# Altium schematic sheet AUDIO&KEY.SchDoc(AUDIO&KEY)
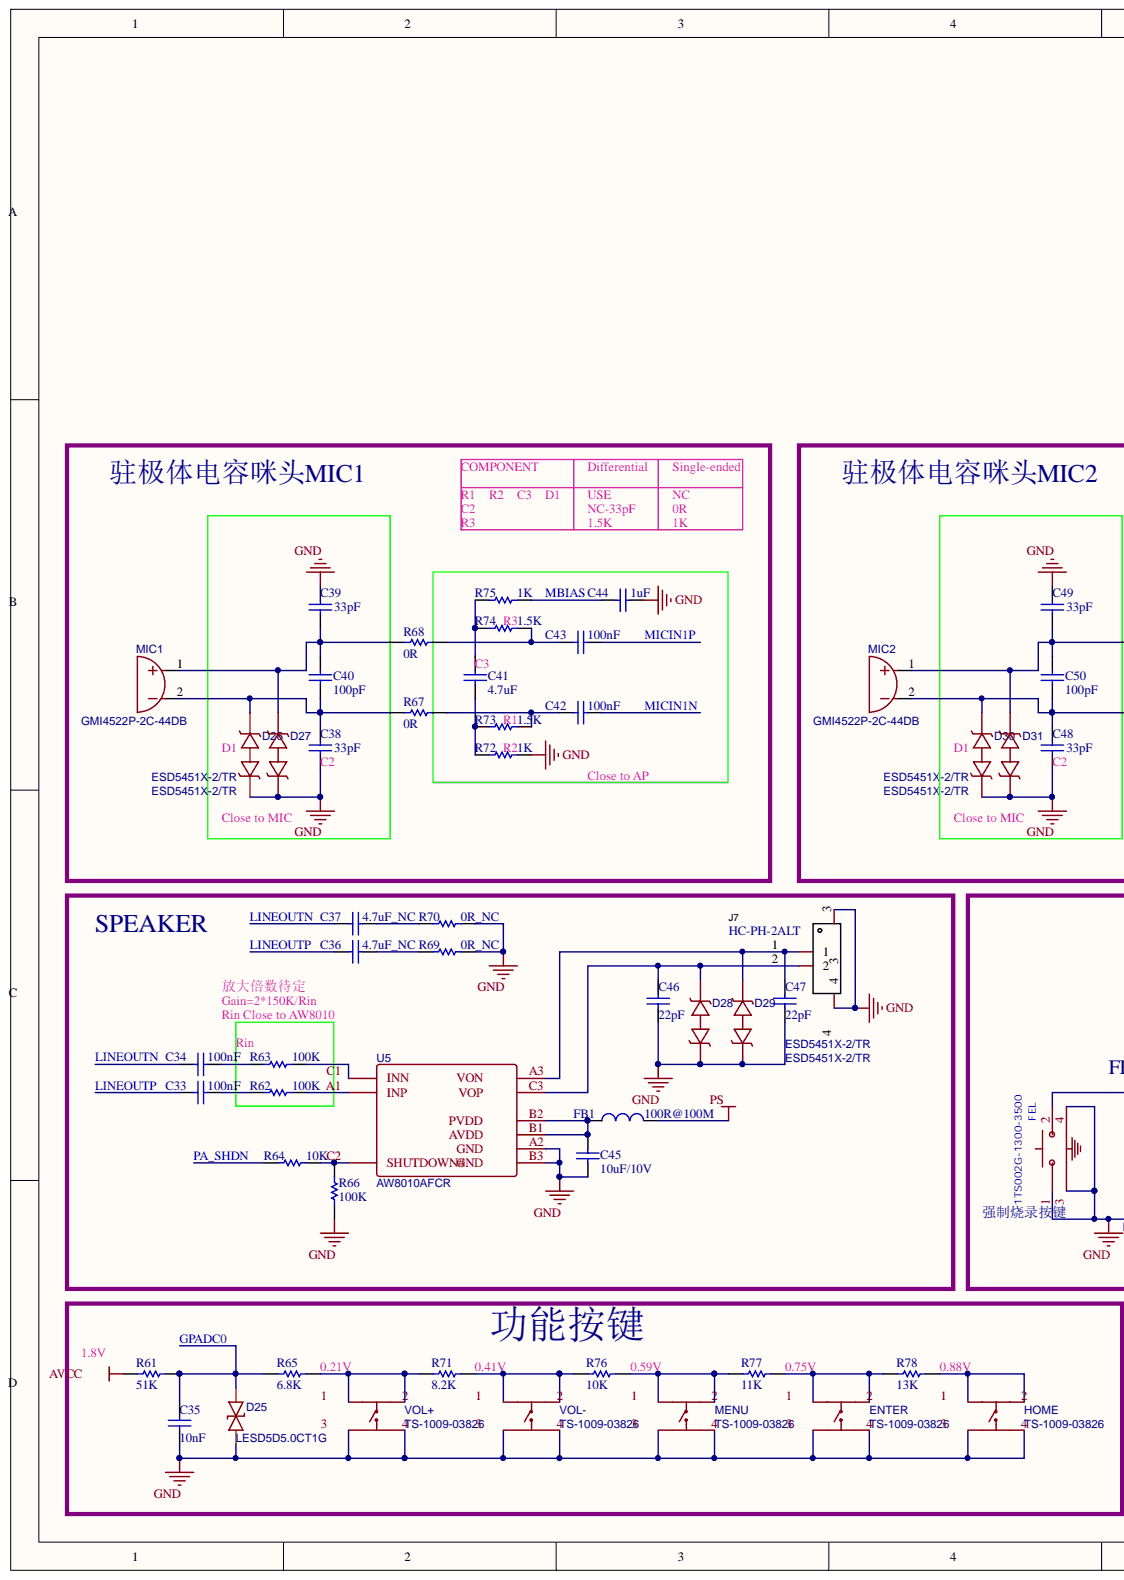
[diagram p0: AUDIO&KEY.SchDoc, left]
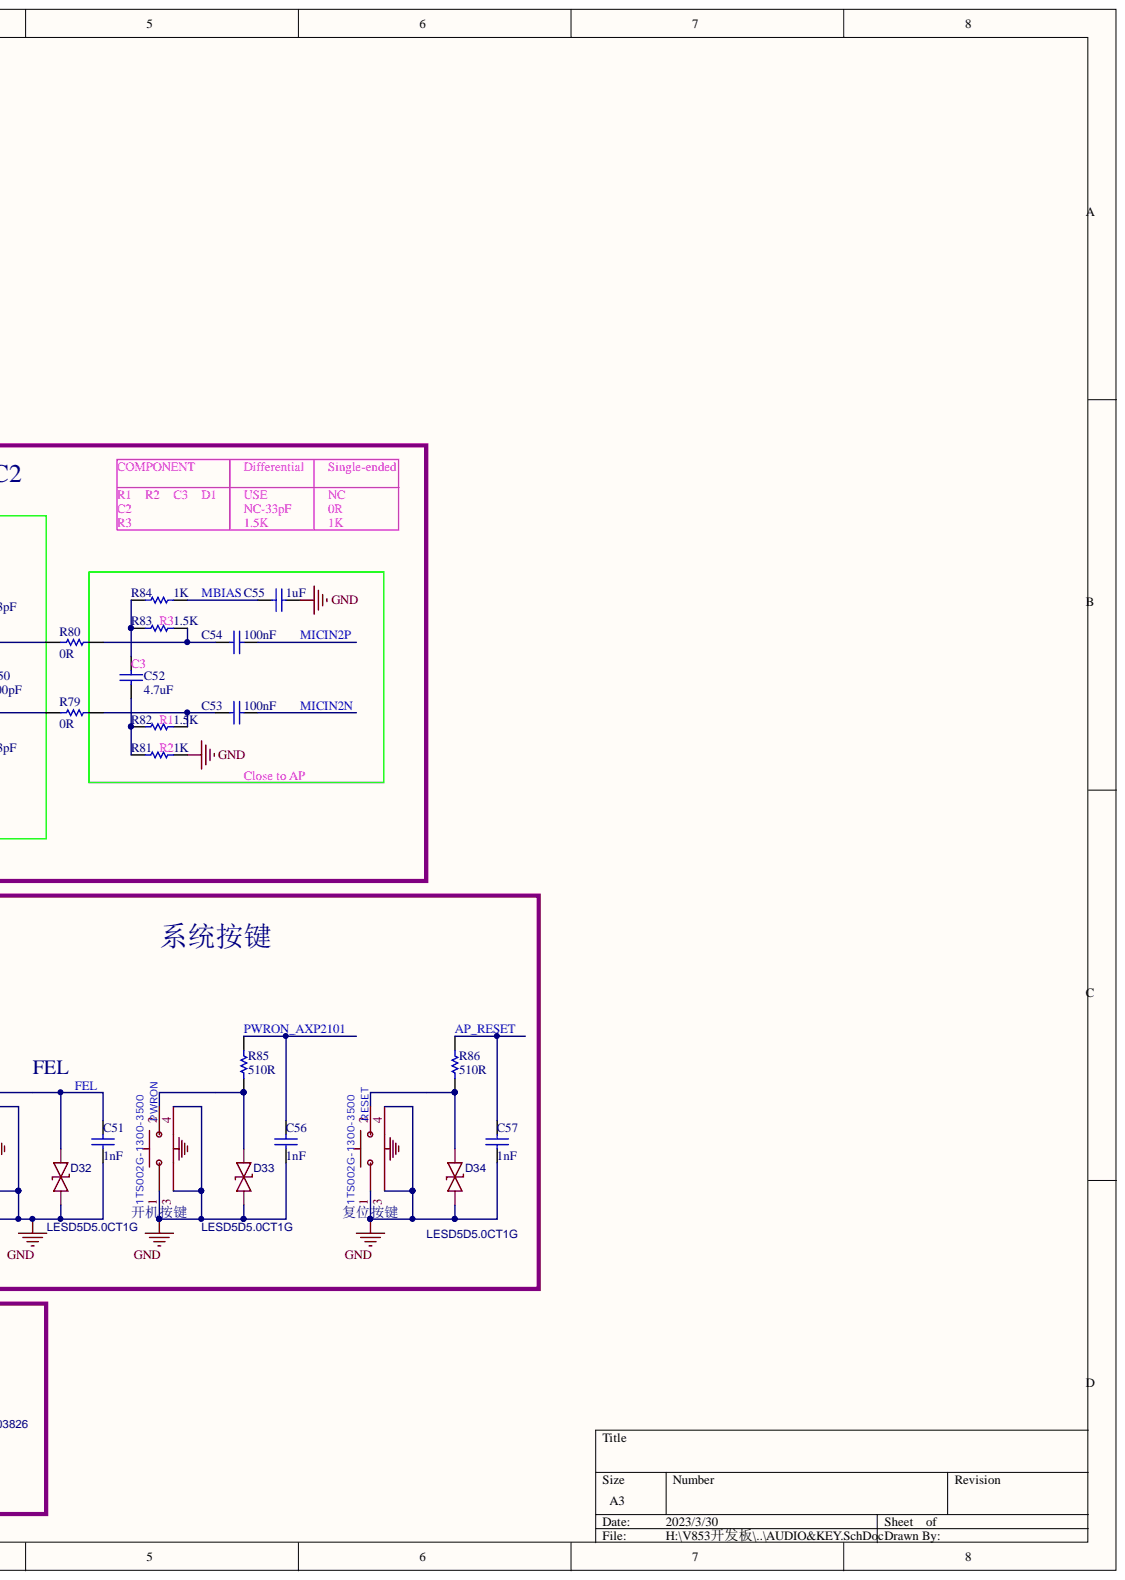
[diagram p1: AUDIO&KEY.SchDoc, right]

## Parts
C33  100nF  [0402]
C34  100nF  [0402]
C35  10nF  [0402]
C36  4.7uF_NC  [0402]
C37  4.7uF_NC  [0402]
C38  33pF  [0402]
C39  33pF  [0402]
C40  100pF  [0402]
C41  4.7uF  [0402]
C42  100nF  [0402]
C43  100nF  [0402]
C44  1uF  [0402]
C45  10uF/10V  [0402]
C46  22pF  [0402]
C47  22pF  [0402]
C48  33pF  [0402]
C49  33pF  [0402]
C50  100pF  [0402]
C51  1nF  [0402]
C52  4.7uF  [0402]
C53  100nF  [0402]
C54  100nF  [0402]
C55  1uF  [0402]
C56  1nF  [0402]
C57  1nF  [0402]
D25  LESD5D5.0CT1G  [SOD-523_L1.2-W0.8-LS1.6-BI]
D26  ESD5451X-2/TR  [FBP-02C_L1.0-W0.6-RD]
D27  ESD5451X-2/TR  [FBP-02C_L1.0-W0.6-RD]
D28  ESD5451X-2/TR  [FBP-02C_L1.0-W0.6-RD]
D29  ESD5451X-2/TR  [FBP-02C_L1.0-W0.6-RD]
D30  ESD5451X-2/TR  [FBP-02C_L1.0-W0.6-RD]
D31  ESD5451X-2/TR  [FBP-02C_L1.0-W0.6-RD]
D32  LESD5D5.0CT1G  [SOD-523_L1.2-W0.8-LS1.6-BI]
D33  LESD5D5.0CT1G  [SOD-523_L1.2-W0.8-LS1.6-BI]
D34  LESD5D5.0CT1G  [SOD-523_L1.2-W0.8-LS1.6-BI]
ENTER  TS-1009-03826  [SW-TH_4P-L4.5-W4.5-P3.00-LS5.5]
FB1  100R@100M  [0603]
FEL  1TS002G-1300-3500  [SW-SMD_1TS002G-XXXX-3500-CT]
HOME  TS-1009-03826  [SW-TH_4P-L4.5-W4.5-P3.00-LS5.5]
J7  HC-PH-2ALT  [CONN-SMD_HC-PH-2ALT]
MENU  TS-1009-03826  [SW-TH_4P-L4.5-W4.5-P3.00-LS5.5]
MIC1  GMI4522P-2C-44DB  [MIC-TH_BD4.5-P1.60-D0.40-L-FD]
MIC2  GMI4522P-2C-44DB  [MIC-TH_BD4.5-P1.60-D0.40-L-FD]
PWRON  1TS002G-1300-3500  [SW-SMD_1TS002G-XXXX-3500-CT]
R61  51K  [0402]
R62  100K  [0402]
R63  100K  [0402]
R64  10K  [0402]
R65  6.8K  [0402]
R66  100K  [0402]
R67  0R  [0402]
R68  0R  [0402]
R69  0R_NC  [0402]
R70  0R_NC  [0402]
R71  8.2K  [0402]
R72  1K  [0402]
R73  1.5K  [0402]
R74  1.5K  [0402]
R75  1K  [0402]
R76  10K  [0402]
R77  11K  [0402]
R78  13K  [0402]
R79  0R  [0402]
R80  0R  [0402]
R81  1K  [0402]
R82  1.5K  [0402]
R83  1.5K  [0402]
R84  1K  [0402]
R85  510R  [0402]
R86  510R  [0402]
RESET  1TS002G-1300-3500  [SW-SMD_1TS002G-XXXX-3500-CT]
U5  AW8010AFCR  [FC-9_L1.5-W1.5-R3-C3-P0.50-BL]
VOL+  TS-1009-03826  [SW-TH_4P-L4.5-W4.5-P3.00-LS5.5]
VOL-  TS-1009-03826  [SW-TH_4P-L4.5-W4.5-P3.00-LS5.5]

## Nets
AVCC: R61.2
GND: C35.2 C38.2 C39.1 C44.1 C45.2 C46.2 C47.2 C48.2 C49.1 C51.2 C55.1 C56.2 C57.2 D25.1 D26.1 D27.1 D28.1 D29.1 D30.1 D31.1 D32.1 D33.1 D34.1 ENTER.3 ENTER.4 FEL.1 FEL.3 FEL.4 HOME.3 HOME.4 J7.3 J7.4 MENU.3 MENU.4 PWRON.1 PWRON.3 PWRON.4 R66.1 R69.2 R70.2 R72.2 R81.2 RESET.1 RESET.3 RESET.4 U5.A2 U5.B3 VOL+.3 VOL+.4 VOL-.3 VOL-.4
PS: FB1.2
AP_RESET: C57.1 R86.1
FEL: C51.1 D32.2 FEL.2
GPADC0: C35.1 D25.2 R61.1 R65.2
LINEOUTN: C34.2 C37.2
LINEOUTP: C33.2 C36.2
MBIAS: C44.2 C55.2 R75.2 R84.2
MICIN1N: C42.1
MICIN1P: C43.1
MICIN2N: C53.1
MICIN2P: C54.1
PA_SHDN: R64.1
PWRON_AXP2101: C56.1 R85.1
NetC33_1: C33.1 R62.1
NetC34_1: C34.1 R63.1
NetC36_1: C36.1 R69.1
NetC37_1: C37.1 R70.1
NetC38_1: C38.1 C40.2 D26.2 MIC1.2 R67.1
NetC39_2: C39.2 C40.1 D27.2 MIC1.1 R68.1
NetC41_1: C41.1 R74.1 R75.1
NetC41_2: C41.2 R72.1 R73.1
NetC42_2: C42.2 R67.2 R73.2
NetC43_2: C43.2 R68.2 R74.2
NetC45_1: C45.1 FB1.1 U5.B1 U5.B2
NetC46_1: C46.1 D28.2 J7.2 U5.C3
NetC47_1: C47.1 D29.2 J7.1 U5.A3
NetC48_1: C48.1 C50.2 D30.2 MIC2.2 R79.1
NetC49_2: C49.2 C50.1 D31.2 MIC2.1 R80.1
NetC52_1: C52.1 R83.1 R84.1
NetC52_2: C52.2 R81.1 R82.1
NetC53_2: C53.2 R79.2 R82.2
NetC54_2: C54.2 R80.2 R83.2
NetD33_2: D33.2 PWRON.2 R85.2
NetD34_2: D34.2 R86.2 RESET.2
NetENTER_1: ENTER.1 ENTER.2 R77.1 R78.2
NetHOME_1: HOME.1 HOME.2 R78.1
NetMENU_1: MENU.1 MENU.2 R76.1 R77.2
NetR62_2: R62.2 U5.A1(INP)
NetR63_2: R63.2 U5.C1(INN)
NetR64_2: R64.2 R66.2 U5.C2(SHUTDOWN#)
NetR65_1: R65.1 R71.2 VOL+.1 VOL+.2
NetR71_1: R71.1 R76.2 VOL-.1 VOL-.2

## Library data of the parts
C33-C57 = Cap: Capacitor; PartNumber: CAP100NF; Component Kind: Standard; LatestRevisionDate: 17-Jul-2002; LatestRevisionNote: Re-released for DXP Platform.; Library Name: Miscellaneous Devices.IntLib; PackageReference: 0402; Published: 8-Jun-2000; Signal Integrity: Cap; Simulation: CAP; Publisher: Altium Limited
  C33 C34 C42 C43 C53 C54: Value: 100nF
  C35: Value: 10nF
  C36 C37: Value: 4.7uF_NC
  C38 C39 C48 C49: Value: 33pF
  C40 C50: Value: 100pF
  C41 C52: Value: 4.7uF
  C44 C55: Value: 1uF
  C45: Value: 10uF/10V
  C46 C47: Value: 22pF
  C51 C56 C57: Value: 1nF
D25 D32-D34 = LESD5D5.0CT1G: BOM_Manufacturer: Leshan Radio; Contributor: LCSC; BOM_JLCPCB Part Class: Extended Part; BOM_Manufacturer Part: LESD5D5.0CT1G; BOM_Supplier: LCSC; BOM_Supplier Part: C383211; Component Kind: Standard; spicePre: D; spiceSymbolName: LESD5D5.0CT1G
D26-D31 = ESD5451X-2/TR: BOM_Manufacturer: Will Semicon; Contributor: LCSC; BOM_JLCPCB Part Class: Extended Part; BOM_Manufacturer Part: ESD5451X-2/TR; BOM_Supplier: LCSC; BOM_Supplier Part: C239558; Component Kind: Standard; spicePre: D; spiceSymbolName: ESD5451X-2/TR
ENTER HOME MENU VOL+ VOL- = KEY_C174049: BOM_Manufacturer: BOOMELE(Boom Precision Elec); Contributor: LCSC; SMT Type: Extend; BOM_JLCPCB SMD: Yes; BOM_Supplier: LCSC; BOM_Supplier Part: C455237; Component Kind: Standard; Library Name: HM2.SchLib; spicePre: S; spiceSymbolName: C455237
FB1 = Inductor: Component Kind: Standard; Library Name: MyDevice.SchLib; Value: 100R@100M
J7 = HC-PH-2ALT: Add into BOM: yes; Current Rating (Max): 2A; Number of PINs Per Row: 2; Pins Structure: 1x2P; Pitch: 2mm; Component Kind: Standard; Convert to PCB: yes; Datasheet: https://atta.szlcsc.com/upload/public/pdf/source/20210728/C2845451_BA459BCD93EBE6AA1DA880EFD46E3DBA.pdf; Device: HC-PH-2ALT; JLCPCB Part Class: Extended Part; Manufacturer Part: HC-PH-2ALT; Name: HC-PH-2ALT; Number of Rows: 1; PCB3D: CONN-SMD_HC-PH-2ALT; Reference Series: -; Row Spacing: -; Supplier: LCSC; Supplier Footprint: SMD,P=2mm; Supplier Part: C2845451; Symbol: HC-PH-2ALT
MIC1 MIC2 = GMI4522P-2C-44DB: BOM_Manufacturer: INGHAi; Contributor: LCSC; BOM_JLCPCB Part Class: Extended Part; BOM_Manufacturer Part: GMI4522P-2C-44db; BOM_Supplier: LCSC; BOM_Supplier Part: C233312; Component Kind: Standard; spicePre: M; spiceSymbolName: GMI4522P-2C-44DB
R61-R86 = Res1: Resistor; PartNumber: RES2.2K; Component Kind: Standard; LatestRevisionDate: 17-Jul-2002; LatestRevisionNote: Re-released for DXP Platform.; Library Name: Miscellaneous Devices.IntLib; PackageReference: 0402; Published: 8-Jun-2000; Signal Integrity: Res; Simulation: RESISTOR; Publisher: Altium Limited
  R61: Value: 51K
  R62 R63 R66: Value: 100K
  R64 R76: Value: 10K
  R65: Value: 6.8K
  R67 R68 R79 R80: Value: 0R
  R69 R70: Value: 0R_NC
  R71: Value: 8.2K
  R72 R75 R81 R84: Value: 1K
  R73 R74 R82 R83: Value: 1.5K
  R77: Value: 11K
  R78: Value: 13K
  R85 R86: Value: 510R
U5 = AW8010AFCR: BOM_Manufacturer: AWINIC; Contributor: LCSC; BOM_JLCPCB Part Class: Extended Part; BOM_Manufacturer Part: AW8010AFCR; BOM_Supplier: LCSC; BOM_Supplier Part: C164085; Component Kind: Standard; Library Name: HM2.SchLib; spicePre: U; spiceSymbolName: AW8010AFCR
FEL PWRON RESET = 1TS002G-1300-3500: Component Kind: Standard; JLC NO: C255803
